# Altium schematic sheet [1] PowerSupply.SchDoc("[1] PowerSupply")
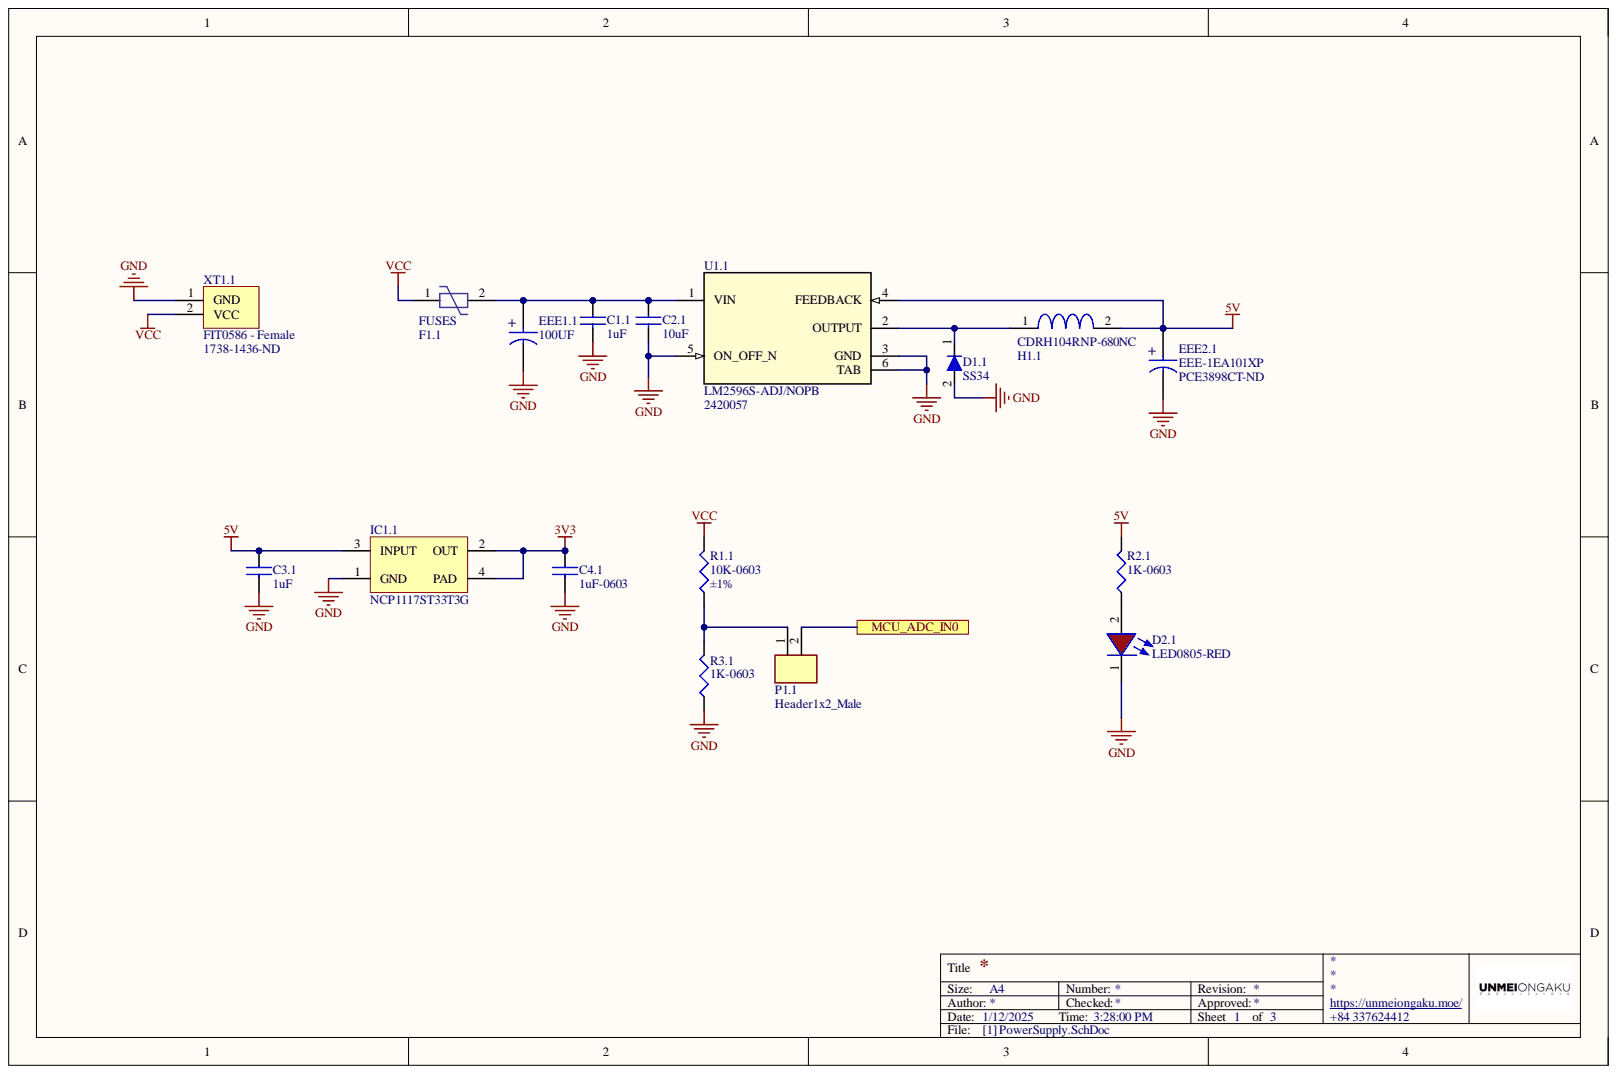
[diagram p0: [1] PowerSupply.SchDoc]

## Parts
C1.1  1uF  [CAP0603]
C2.1  10uF  [CAP0603]
C3.1  1uF  [CAP0603]
C4.1  1uF-0603  [CAP0603]
D1.1  SS34  [SS34 - 1N5822 / DO-214AA, SMA]
D2.1  LED0805-RED  [LED0805-RED]
EEE1.1  100UF  [CAPACITOR/ALU - SIZE D/SMD - 6.3X5.4]
EEE2.1  EEE-1EA101XP  Supplier Part Number 1: PCE3898CT-ND  [CAPACITOR/ALU - SIZE D8/SMD - 6.3X7.7]
F1.1  FUSES  [FUSES - SMD1812]
H1.1  CDRH104RNP-680NC  [CDRH104RNP]
IC1.1  NCP1117ST33T3G  [SOT223-4M_AMS1117_3V3]
P1.1  Header1x2_Male  [HDR1x2_2.54_MALE]
R1.1  10K-0603  Tolerance: ±1%  [RES0603 - 3D]
R2.1  1K-0603  [RES0603 - 3D]
R3.1  1K-0603  [RES0603 - 3D]
U1.1  LM2596S-ADJ/NOPB  Supplier Part Number 1: 2420057  [TO265-5]
XT1.1  FIT0586 - Female  Manufacturer Part Number: FIT0586  Supplier Part Number 1: 1738-1436-ND  [XT30U-M]

## Ports
MCU_ADC_IN0

## Nets
3V3: C4.1.1 IC1.1.2(OUT) IC1.1.4(PAD)
5V: C3.1.1 EEE2.1.1 H1.1.2 IC1.1.3(INPUT) R2.1.2 U1.1.4(FEEDBACK)
GND: C1.1.2 C2.1.2 C3.1.2 C4.1.2 D1.1.2 D2.1.1 EEE1.1.2 EEE2.1.2 IC1.1.1(GND) R3.1.1 U1.1.3(GND) U1.1.5(ON_OFF_N) U1.1.6(TAB) XT1.1.1
VCC: F1.1.1 R1.1.2 XT1.1.2
MCU_ADC_IN0: P1.1.2 ; port MCU_ADC_IN0
NetC1.1_1: C1.1.1 C2.1.1 EEE1.1.1 F1.1.2 U1.1.1(VIN)
NetD1.1_1: D1.1.1 H1.1.1 U1.1.2(OUTPUT)
NetD2.1_2: D2.1.2 R2.1.1
NetP1.1_1: P1.1.1 R1.1.1 R3.1.2

## Library data of the parts
C1.1 C3.1 C4.1 = CC0603KRX7R7BB105: CAP CER 1UF 16V X7R 0603; Manufacturer 1: Yageo; Capacitance: 1µF; Category 1: Capacitors; Tolerance: ±10%; Applications: General Purpose; Component Kind: Standard; ComponentLink1Description: http://www.yageo.com/documents/recent/UPY-GPHC_X7R_6.3V-to-50V_18.pdf; ComponentLink1URL: http://www.yageo.com/documents/recent/UPY-GPHC_X7R_6.3V-to-50V_18.pdf; Description 1: CAP CER 1UF 16V X7R 0603; Failure Rate: -; Features: -; Height - Seated (Max): -; Lead Spacing: -; Lead Style: -; Manufacturer Part Number 1: CC0603KRX7R7BB105; Mounting Type: Surface Mount, MLCC; Operating Temperature: -55°C ~ 125°C; Package / Case: 0603 (1608 Metric); Packaging: Cut Tape (CT); Part Status: Active; Pricing 1: 1=0.1, 10=0.051, 100=0.0227, 500=0.0162, 1000=0.01274 (USD); Ratings: -; RoHS 1: RoHS Compliant; Size / Dimension: 0.063" L x 0.031" W (1.60mm x 0.80mm); Stock 1: 274580; Supplier 1: Digi-Key; Supplier Part Number 1: 311-1446-1-ND; Temperature Coefficient: X7R; Thickness (Max): 0.035" (0.90mm); Value: 1uF; Voltage - Rated: 16V; Library Name: Minh_Lib.SchLib
C2.1 = CC0603KRX5R6BB106: CAP CER 10UF 10V X5R 0603; Manufacturer 1: Yageo; Capacitance: 10µF; Category 1: Capacitors; Tolerance: ±10%; Applications: General Purpose; Component Kind: Standard; ComponentLink1Description: http://www.yageo.com/documents/recent/UPY-GPHC_X5R_4V-to-50V_24.pdf; ComponentLink1URL: http://www.yageo.com/documents/recent/UPY-GPHC_X5R_4V-to-50V_24.pdf; Description 1: CAP CER 10UF 10V X5R 0603; Failure Rate: -; Features: -; Height - Seated (Max): -; Lead Spacing: -; Lead Style: -; Manufacturer Part Number 1: CC0603KRX5R6BB106; Mounting Type: Surface Mount, MLCC; Operating Temperature: -55°C ~ 85°C; Package / Case: 0603 (1608 Metric); Packaging: Cut Tape (CT); Part Status: Active; Pricing 1: 1=0.33, 10=0.225, 100=0.1272, 500=0.08982, 1000=0.07858 (USD); Ratings: -; RoHS 1: RoHS Compliant; Size / Dimension: 0.063" L x 0.031" W (1.60mm x 0.80mm); Stock 1: 66566; Supplier 1: Digi-Key; Supplier Part Number 1: 311-1782-1-ND; Temperature Coefficient: X5R; Thickness (Max): 0.035" (0.90mm); Value: 10uF; Voltage - Rated: 10V; Library Name: Minh_Lib.SchLib
D1.1 = SS34: SS Series 500 mV 3 A 40 V Max Reverse Voltage Schottky Rectifier - DO-214AB; Manufacturer: ON Semiconductor / Fairchild; Average Rectified Current: 3A; Diode Element Material: SILICON; Element Configuration: Single; Forward Voltage: 500mV; Max Forward Surge Current (Ifsm): 100A; Max Power Dissipation: 2.27W; Max Repetitive Reverse Voltage (Vrrm): 40V; Max Reverse Current: 500uA; Number of Elements: 1; Number of Terminals/Pins: 2; Package Body Material: Plastic; Polarity: Standard; Case/Package: SMC; Category: Schottky Diodes; Component Kind: Standard; Contact Plating: Tin,Matte; Current: 3A; Current Rating: 3A; Forward Current: 3A; Height: 2.75mm; JEDEC-95 Code: DO-214AB; JESD-30 Code: R-PDSO-C2; Lead Free: Lead Free; Length: 7.15mm; Manufacturer Part Number: SS34; Max Operating Temperature: 150°C; Max Reverse Leakage Current: 500uA; Max Reverse Voltage (DC): 40V; Max Surge Current: 100A; Min Operating Temperature: -55°C; Moisture Sensitivity Level: 1; Mount: Surface Mount; Number of Phases: 1; Number of Pins: 2; Output Current: 3A; Package Quantity: 1; Package Shape: Rectangular; Packaging: Tape and Reel; Peak Non-Repetitive Surge Current: 100A; Peak Reflow Temperature (Cel): 260°C; Pricing 1: 1=0.65000, 25=0.57600, 50=0.50900, 100=0.44100, 250=0.39500, 500=0.34900, 1000=0.27900 (USD); Radiation Hardening: No; Reach SVHC Compliant: Yes; RoHS: Yes; RoHS Compliant: Yes; Series: -; Stock 1: 7040; Supplier 1: Newark; Supplier Part Number 1: 18C8659; Surface Mount: Yes; Technology: SCHOTTKY; Terminal Finish: Tin; Terminal Form: C BEND; Terminal Position: DUAL; Voltage: 40V; Voltage Rating (DC): 40V; Width: 6.25mm; Library Name: Minh_Lib.SchLib
EEE1.1 = EEE-1AA101SP: Cap Aluminum 100uF 10V 20% (6.3 X 5.4mm) SMD 70mA 2000 hr 85°C T/R; Manufacturer: Panasonic; Leakage Current: 3uA; Life (Hours): 2000Hour; Polarity: Polar; Capacitance: 100uF; Case/Package: Radial; Category: Aluminum Electrolytic Capacitors; Component Kind: Standard; ComponentLink1Description: https://datasheet.ciiva.com/43470/aba0000ce2-43470621.pdf?src-supplier=Farnell; ComponentLink1URL: https://datasheet.ciiva.com/43470/aba0000ce2-43470621.pdf?src-supplier=Farnell; ComponentLink2Description: https://datasheet.ciiva.com/46378/aba0000ce2-46378266.pdf?src-supplier=Element14; ComponentLink2URL: https://datasheet.ciiva.com/46378/aba0000ce2-46378266.pdf?src-supplier=Element14; ComponentLink3Description: https://datasheet.ciiva.com/31414/aba0000ce2-31414629.pdf?src-supplier=Farnell; ComponentLink3URL: https://datasheet.ciiva.com/31414/aba0000ce2-31414629.pdf?src-supplier=Farnell; ComponentLink4Description: https://datasheet.ciiva.com/46374/aba0000ce2-46374349.pdf?src-supplier=Element14; ComponentLink4URL: https://datasheet.ciiva.com/46374/aba0000ce2-46374349.pdf?src-supplier=Element14; ComponentLink5Description: https://datasheet.ciiva.com/14598/70255710-14598444.pdf?src-supplier=Allied+Electronics; ComponentLink5URL: https://datasheet.ciiva.com/14598/70255710-14598444.pdf?src-supplier=Allied+Electronics; ComponentLink6Description: https://datasheet.ciiva.com/14604/70255710-14604862.pdf?src-supplier=Allied+Electronics; ComponentLink6URL: https://datasheet.ciiva.com/14604/70255710-14604862.pdf?src-supplier=Allied+Electronics; ComponentLink7Description: https://datasheet.ciiva.com/2983/aba0000ce2-2983905.pdf?src-supplier=Avnet; ComponentLink7URL: https://datasheet.ciiva.com/2983/aba0000ce2-2983905.pdf?src-supplier=Avnet; ComponentLink8Description: https://datasheet.ciiva.com/15166/getdatasheetpartid-178534-15166250.pdf?src-supplier=Verical; ComponentLink8URL: https://datasheet.ciiva.com/15166/getdatasheetpartid-178534-15166250.pdf?src-supplier=Verical; ComponentLink9Description: https://datasheet.ciiva.com/2985/aba0000ce2-2985040.pdf?src-supplier=Avnet; ComponentLink9URL: https://datasheet.ciiva.com/2985/aba0000ce2-2985040.pdf?src-supplier=Avnet; ComponentLink10Description: https://datasheet.ciiva.com/30099/11aba0000ce2-30099766.pdf?src-supplier=Onlinecomponents.com; ComponentLink10URL: https://datasheet.ciiva.com/30099/11aba0000ce2-30099766.pdf?src-supplier=Onlinecomponents.com; ComponentLink11Description: https://datasheet.ciiva.com/17536/aba0000ce2-17536070.pdf?src-supplier=Avnet+Europe; ComponentLink11URL: https://datasheet.ciiva.com/17536/aba0000ce2-17536070.pdf?src-supplier=Avnet+Europe; Construction: Cylindrical; Contact Plating: Tin; Diameter: 6.3mm; Features: General Purpose; Height: 5.4mm; Height - Seated (Max): 0.213inch; Lead Free: Lead Free; Length: 5.5mm; Manufacturer Part Number: EEE-1AA101SP; Max Operating Temperature: 85°C; Min Operating Temperature: -40°C; Mount: Surface Mount,PCB; Package Quantity: 1000; Packaging: Tape and Reel; Pricing 1: 1=0.38000, 10=0.26400, 50=0.18520, 100=0.15870, 500=0.11902 (USD); Radiation Hardening: No; REACH SVHC: Unknown; Ripple Current: 70mA; Ripple Current (AC): 70mA; RoHS: Yes; RoHS Compliant: Yes; Series: S; Supplier 1: Digi-Key; Supplier Part Number 1: PCE3866DKR-ND; Termination: SMD/SMT; Tolerance: 20%; Voltage: 10V; Voltage Rating: 10V; Voltage Rating (DC): 10V; Library Name: Minh_Lib.SchLib
EEE2.1 = EEE-1EA101XP: Cap Aluminum 100uF 25V 20% (6.3 X 7.7mm) SMD 143mA 2000h 85°C T/R; Manufacturer: Panasonic; Leakage Current: 3uA; Life (Hours): 2000Hour; Polarity: Polar; Capacitance: 100uF; Case/Package: Radial; Category: Aluminum Electrolytic Capacitors; Component Kind: Standard; ComponentLink1Description: https://datasheet.ciiva.com/24022/aba0000ce2-40801-24022875.pdf?src-supplier=Mouser; ComponentLink1URL: https://datasheet.ciiva.com/24022/aba0000ce2-40801-24022875.pdf?src-supplier=Mouser; ComponentLink2Description: https://datasheet.ciiva.com/16201/aba0000ce2-16201065.pdf?src-supplier=Future+Electronics; ComponentLink2URL: https://datasheet.ciiva.com/16201/aba0000ce2-16201065.pdf?src-supplier=Future+Electronics; ComponentLink3Description: https://datasheet.ciiva.com/30108/11aba0000ce2-30108047.pdf?src-supplier=Onlinecomponents.com; ComponentLink3URL: https://datasheet.ciiva.com/30108/11aba0000ce2-30108047.pdf?src-supplier=Onlinecomponents.com; ComponentLink4Description: https://datasheet.ciiva.com/17529/aba0000ce2-17529557.pdf?src-supplier=Avnet+Europe; ComponentLink4URL: https://datasheet.ciiva.com/17529/aba0000ce2-17529557.pdf?src-supplier=Avnet+Europe; Construction: Cylindrical; Contact Plating: Tin; Diameter: 6.3mm; Height: 7.7mm; Height - Seated (Max): 0.303inch; Lead Free: Lead Free; Length: 8mm; Manufacturer Part Number: EEE-1EA101XP; Max Operating Temperature: 85°C; Min Operating Temperature: -40°C; Mount: Surface Mount,PCB; Package Quantity: 900; Packaging: Tape and Reel; Pricing 1: 1=0.52000, 10=0.36100, 50=0.25240, 100=0.21630 (USD); Radiation Hardening: No; REACH SVHC: Unknown; Ripple Current: 143mA; Ripple Current (AC): 143mA; RoHS: Yes; RoHS Compliant: Yes; Series: S; Supplier 1: Digi-Key; Termination: SMD/SMT; Tolerance: 20%; Voltage: 25V; Voltage Rating: 25V; Voltage Rating (DC): 25V; Library Name: Minh_Lib.SchLib
F1.1 = FUSES-SMD1812: Component Kind: Standard; Library Name: Minh_Lib.SchLib
H1.1 = CDRH104RNP-680NC: Ind Power Shielded Wirewound 68uH 30% 100KHz Ferrite 1.42A Carrier T/R; Manufacturer: Sumida; DC Resistance (DCR): 213mR; Rated Current-Max: 1.5A; Case/Package: Nonstandard; Category: Fixed Inductors; Component Kind: Standard; ComponentLink1Description: http://www.sumida.com/products/pdf/CDRH104,104R.pdf?src-supplier=Digi-Key; ComponentLink1URL: http://www.sumida.com/products/pdf/CDRH104,104R.pdf?src-supplier=Digi-Key; ComponentLink2Description: http://products.sumida.com/products/pdf/CDRH104R.pdf?src-supplier=Digi-Key; ComponentLink2URL: http://products.sumida.com/products/pdf/CDRH104R.pdf?src-supplier=Digi-Key; ComponentLink3Description: http://www.sumida.com/products/pdf/CDRH104,104R.pdf?src-supplier=Mouser; ComponentLink3URL: http://www.sumida.com/products/pdf/CDRH104,104R.pdf?src-supplier=Mouser; ComponentLink4Description: http://www.sumida.com/products/pdf/CDRH104R.pdf?src-supplier=Avnet; ComponentLink4URL: http://www.sumida.com/products/pdf/CDRH104R.pdf?src-supplier=Avnet; ComponentLink5Description: http://www.sumida.com/products/pdf/CDRH104,104R.pdf?src-supplier=Future+Electronics; ComponentLink5URL: http://www.sumida.com/products/pdf/CDRH104,104R.pdf?src-supplier=Future+Electronics; Core Material: Ferrite; Current Rating: 1.42A; Depth: 10.3mm; Height: 4mm; Height - Seated (Max): 0.157inch; Inductance: 68uH; Lead Free: Lead Free; Length: 0.402inch; Manufacturer Part Number: CDRH104RNP-680NC; Max DC Current: 1.42A; Max Operating Temperature: 100°C; Min Operating Temperature: -40°C; Mount: Surface Mount; Package Quantity: 1000; Packaging: Tape and Reel; Pricing 1: 1=1.78000, 10=1.64700, 25=1.51000, 50=1.29060, 100=1.15320, 250=0.98848, 500=0.93356 (USD); Radiation Hardening: No; RoHS: Yes; RoHS Compliant: Yes; Saturation Current: 1.5A; Series: CDRH; Shielding: Shielded; Stock 1: 26557; Supplier 1: Digi-Key; Supplier Part Number 1: 308-2170-1-ND; Termination: SMD/SMT; Test Frequency: 100kHz; Tolerance: 30%; Width: 0.405inch; Library Name: Minh_Lib.SchLib
IC1.1 = AMS1117 - 3V3: LDO Voltage Regulators 3.3V 1A Positive; Brand: ON Semiconductor; Dropout Voltage: 0.95 V at 100 mA; Dropout Voltage - Max: 1.1 V at 100 mA; Ib - Input Bias Current: 6 mA; Input Voltage MAX: 20 V; Line Regulation: 0.8 mV; Load Regulation: 4.3 mV; Number of Outputs: 1 Output; Output Type: Fixed; Quiescent Current: 10 mA; Voltage Regulation Accuracy: 1 %; Category: LDO Voltage Regulators; Component Kind: Standard; ComponentLink1Description: https://www.mouser.com/ds/2/308/NCP1117-D-81326.pdf; ComponentLink1URL: https://www.mouser.com/ds/2/308/NCP1117-D-81326.pdf; Height: 1.69 mm (Max); Length: 6.7 mm (Max); Manufacturer: ON Semiconductor; Manufacturer Part Number: NCP1117ST33T3G; Maximum Operating Temperature: + 125 C; Minimum Operating Temperature: 0 C; Minimum Order Quantity: 1; Mounting Style: SMD/SMT; Output Current: 1 A; Output Voltage: 3.3 V; Package / Case: SOT-223-3; Packaging: Cut Tape; Polarity: Positive; Pricing 1: 1=0.450, 10=0.366, 100=0.223, 1000=0.173, 4000=0.147, 8000=0.137, 24000=0.130, 48000=0.127 (USD); Reeling: True; RoHS: RoHS Compliant; RoHS - Mouser: Y; Series: NCP1117; Standard Pack Qty: 4000; Stock 1: 0; Supplier 1: Mouser; Supplier Part Number 1: 863-NCP1117ST33T3G; Width: 3.7 mm (Max); Library Name: Minh_Lib.SchLib
P1.1 = HDR1x2_2.54_MALE: Header1x2_2.54_MALE; Component Kind: Standard; Library Name: Minh_Lib.SchLib
R1.1 = RC0603FR-0710KL: RES SMD 10K OHM 1% 1/10W 0603; Manufacturer: Yageo; Power (Watts): 0.1W, 1/10W; Temperature Coefficient: ±100ppm/°C; Category: Resistors; Component Kind: Standard; ComponentLink1Description: http://www.yageo.com/documents/recent/PYu-RC_Group_51_RoHS_L_7.pdf; ComponentLink1URL: http://www.yageo.com/documents/recent/PYu-RC_Group_51_RoHS_L_7.pdf; Composition: Thick Film; Failure Rate: -; Features: Moisture Resistant; Height - Seated (Max): 0.022" (0.55mm); Manufacturer Part Number: RC0603FR-0710KL; Operating Temperature: -55°C ~ 155°C; Package / Case: 0603 (1608 Metric); Packaging: Cut Tape (CT); Part Status: Active; Pricing 1: 1=0.1, 10=0.015, 100=0.006, 1000=0.00268, 2500=0.00233 (USD); RoHS: RoHS Compliant; Size / Dimension: 0.063" L x 0.032" W (1.60mm x 0.80mm); Stock 1: 16545228; Supplier 1: Digi-Key; Supplier Device Package: 0603; Supplier Part Number 1: 311-10.0KHRCT-ND; Value: 10K; Library Name: Minh_Lib.SchLib
R2.1 R3.1 = RC0603JR-071KL: RES SMD 1K OHM 5% 1/10W 0603; Manufacturer 1: Yageo; Category 1: Resistors; Power (Watts): 0.1W, 1/10W; Temperature Coefficient: ±100ppm/°C; Component Kind: Standard; ComponentLink1Description: http://www.yageo.com/documents/recent/PYu-RC_Group_51_RoHS_L_7.pdf; ComponentLink1URL: http://www.yageo.com/documents/recent/PYu-RC_Group_51_RoHS_L_7.pdf; Composition: Thick Film; Description 1: RES SMD 1K OHM 5% 1/10W 0603; Failure Rate: -; Features: Moisture Resistant; Height - Seated (Max): 0.022" (0.55mm); Manufacturer Part Number 1: RC0603JR-071KL; Operating Temperature: -55°C ~ 155°C; Package / Case: 0603 (1608 Metric); Packaging: Cut Tape (CT); Part Status: Active; Pricing 1: 1=0.1, 10=0.011, 100=0.0044, 1000=0.00198, 2500=0.00172 (USD); Resistance (Ohms): 1k; RoHS 1: RoHS Compliant; Size / Dimension: 0.063" L x 0.031" W (1.60mm x 0.80mm); Stock 1: 2853955; Supplier 1: Digi-Key; Supplier Device Package: 0603; Supplier Part Number 1: 311-1.0KGRCT-ND; Tolerance: ±5%; Value: 1K; Library Name: Minh_Lib.SchLib
U1.1 = LM2596S-ADJ/NOPB: Optoisolator 5KV Trans 4SMD / Optocoupler Dc-in 1-CH Transistor Dc-out 4-PIN PDIP SMD; Manufacturer: Texas Instruments; Manufacturer Part Number: PC817XNNIP0F; PartNumber: LM2596SX-ADJ/NOPB; Collector Emitter Saturation Voltage: 200mV; Collector Emitter Voltage (VCEO): 80V; CompType: IC; Fall Time: 18000ns; Forward Current: 50mA; Forward Voltage: 1.4V; Input Type: DC; Max Power Dissipation: 200mW; Number of Channels: 1; Output Current per Channel: 30mA; Reverse Breakdown Voltage: 6V; Alternate_PartNumber: LM2596S-ADJ/NOPB; Case/Package: SMD/SMT; Category: Optocouplers; Collector-emitter Voltage-Max: 200mV; Component Kind: Standard; ComponentLink1Description: https://datasheet.ciiva.com/15119/getdatasheetpartid-299374-15119023.pdf?src-supplier=Verical; ComponentLink1URL: https://datasheet.ciiva.com/15119/getdatasheetpartid-299374-15119023.pdf?src-supplier=Verical; ComponentLink2Description: https://datasheet.ciiva.com/2086/pc817xxnip0f-series-2086508.pdf?src-supplier=Digi-Key; ComponentLink2URL: https://datasheet.ciiva.com/2086/pc817xxnip0f-series-2086508.pdf?src-supplier=Digi-Key; ComponentLink3Description: https://datasheet.ciiva.com/2086/pc817xxnip0f-series-2086507.pdf?src-supplier=Digi-Key; ComponentLink3URL: https://datasheet.ciiva.com/2086/pc817xxnip0f-series-2086507.pdf?src-supplier=Digi-Key; ComponentLink4Description: https://datasheet.ciiva.com/2086/pc817xxnip0f-series-2086509.pdf?src-supplier=Digi-Key; ComponentLink4URL: https://datasheet.ciiva.com/2086/pc817xxnip0f-series-2086509.pdf?src-supplier=Digi-Key; ComponentLink5Description: https://datasheet.ciiva.com/46396/1812378-46396954.pdf?src-supplier=Element14; ComponentLink5URL: https://datasheet.ciiva.com/46396/1812378-46396954.pdf?src-supplier=Element14; ComponentLink6Description: https://datasheet.ciiva.com/46435/1812378-46435723.pdf?src-supplier=Element14; ComponentLink6URL: https://datasheet.ciiva.com/46435/1812378-46435723.pdf?src-supplier=Element14; ComponentLink7Description: https://datasheet.ciiva.com/16475/pc817xnnip0f-16475911.pdf?src-supplier=Future+Electronics; ComponentLink7URL: https://datasheet.ciiva.com/16475/pc817xnnip0f-16475911.pdf?src-supplier=Future+Electronics; Contact Plating: Copper,Tin; Input Current: 50mA; Isolation Voltage: 5kV; Isolation Voltage-Max: 5kV; Lead Free: Lead Free; Manufacturer_Part_Number: LM2596S-ADJ/NOPB; Max Collector Current: 50mA; Max Input Current: 50mA; Max Operating Temperature: 100°C; Max Output Voltage: 80V; Mfr_Name: Texas Instruments; Min Operating Temperature: -30°C; Mount: Surface Mount; MountType: SMT; Number of Elements: 1; Number of Pins: 4; Output Voltage: 80V; Package Quantity: 2000; PackageReference: KTT0005B; Packaging: Tape and Reel; Power Dissipation: 200mW; Pricing 1: 1=0.31700, 25=0.29900, 100=0.21300, 250=0.17700, 500=0.14100, 2000=0.13800 (GBP); Radiation Hardening: No; RefDes: RefDes; Rise Time: 18000ns; RoHS: Y; RoHS Compliant: Yes; Supplier 1: Farnell; Type: DEV; Copyright: Copyright (C) 2021 Ultra Librarian. All rights reserved.; Library Name: Minh_Lib.SchLib
XT1.1 = FIT0586 - Female: High Quality Gold Plated Male and Female Bullet Connector; Manufacturer: DFRobot; Category: Uncategorized; Component Kind: Standard; ComponentLink1Description: https://media.digikey.com/pdf/Data%20Sheets/DFRobot%20PDFs/FIT0586_Web.pdf?src-supplier=Digikey; ComponentLink1URL: https://media.digikey.com/pdf/Data%20Sheets/DFRobot%20PDFs/FIT0586_Web.pdf?src-supplier=Digikey; Pricing 1: 1=1.9 (USD); RoHS: No; Stock 1: 818; Supplier 1: Digikey; Library Name: Minh_Lib.SchLib
D2.1 = LED0805-RED: Component Kind: Standard; Library Name: Minh_Lib.SchLib
